# Altium schematic sheet Schematic.SchDoc
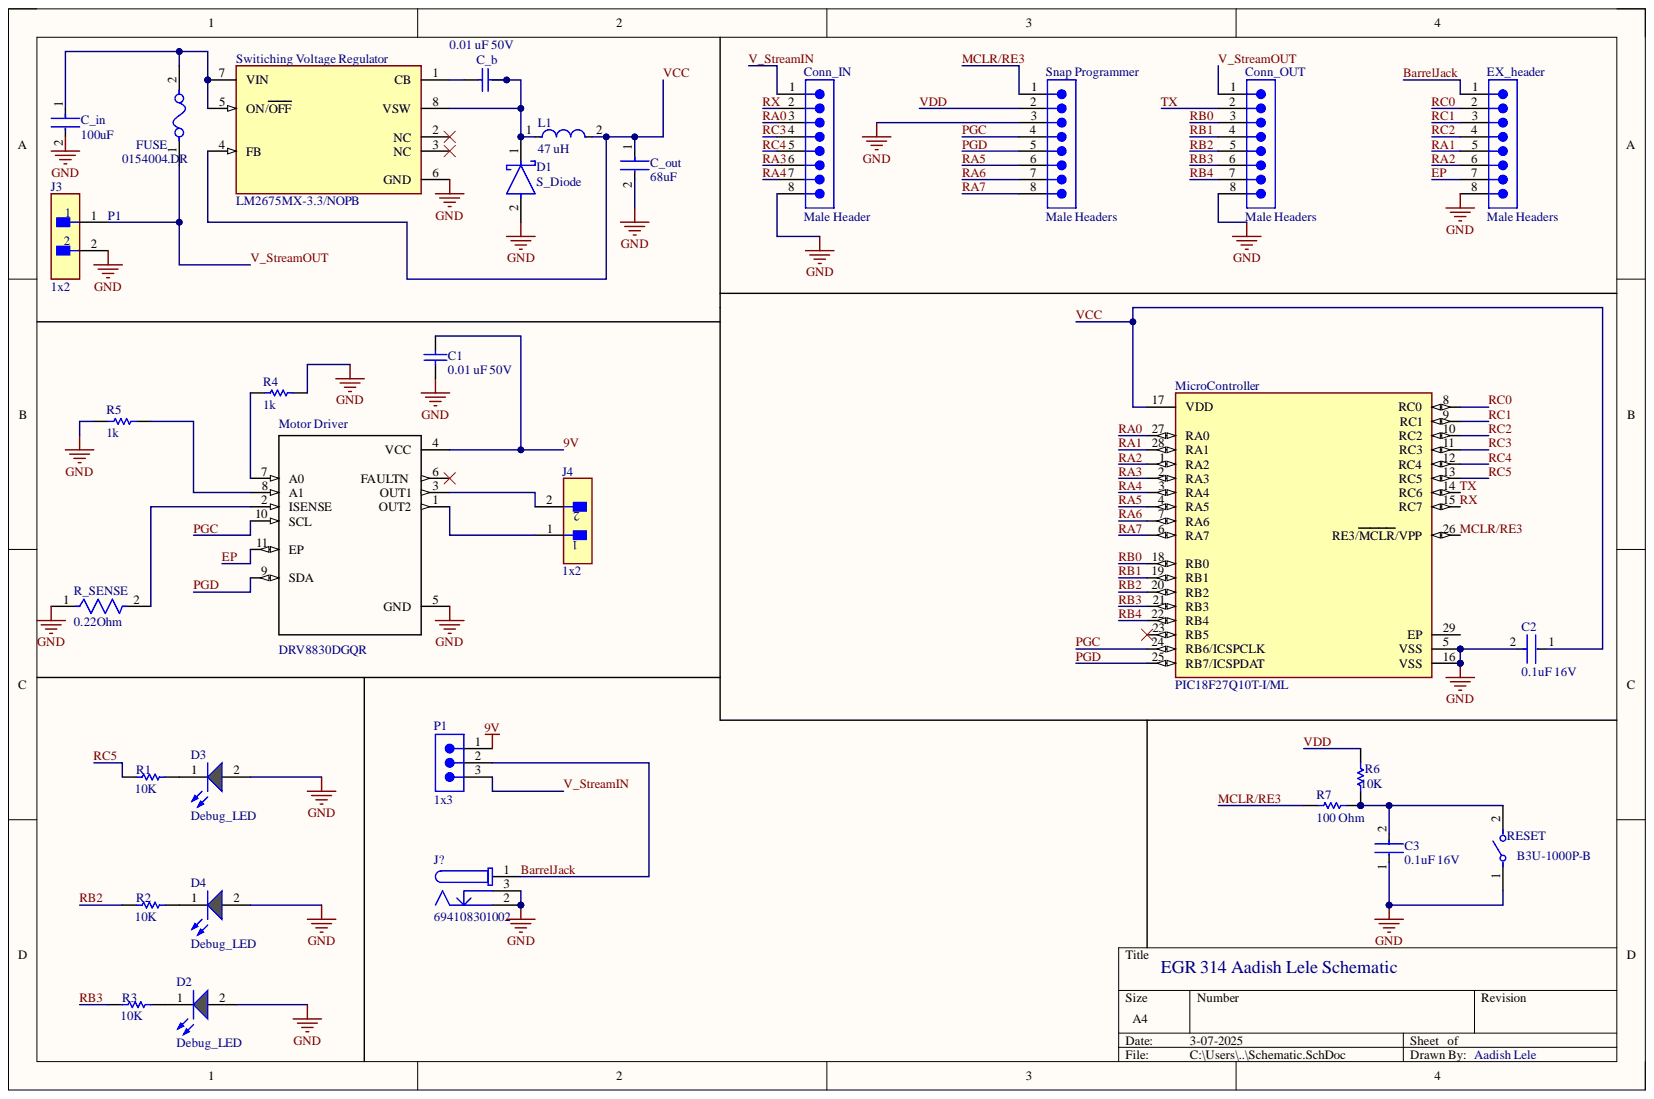
[diagram p0: Schematic.SchDoc]

## Parts
C1  0.01 uF 50V  [CAPC1812(4532)230_M]
C2  0.1uF 16V  [FP-GRM15-MFG]
C3  0.1uF 16V  [FP-GRM15-MFG]
C_b  0.01 uF 50V  [CAPC1812(4532)230_M]
C_in  100uF  [FP-GRM32-0_3-MFG]
C_out  68uF  [FP-C5750-230-0_4-IPC_C]
Conn_IN  Male Header  Gender: Male  [61300811121]
Conn_OUT  Male Headers  Gender: Male  [61300811121]
D1  S_Diode  [FP-SMA-MFG]
D2  Debug_LED  [WL-SMCW_0603_150060xx75000]
D3  Debug_LED  [WL-SMCW_0603_150060xx75000]
D4  Debug_LED  [WL-SMCW_0603_150060xx75000]
EX_header  Male Headers  Gender: Male  [61300811121]
FUSE  0154004.DR  [FP-0154-MFG]
J3  1x2  [FP-90136-1202-MFG]
J4  1x2  [FP-90136-1202-MFG]
J?  694108301002  [694108301002]
L1  47 uH  [FP-LB3218-1_8-0_2-IPC_A]
MicroController  PIC18F27Q10T-I/ML  [FP-C04-105-MFG]  (value not drawn)
Motor_Driver  DRV8830DGQR  [*IC_XTR111AIDGQR]
P1  1x3  [SMTC-TMM-103-01-X-S-RA]
R1  10K  [RESC3116X65X40ML10T20]
R2  10K  [RESC3116X65X40ML10T20]
R3  10K  [RESC3116X65X40ML10T20]
R4  1k  [RESC1005X40X25NL05T05]
R5  1k  [RESC1005X40X25NL05T05]
R6  10K  [RESC3116X65X40ML10T20]
R7  100 Ohm  [RESC3216X70X50NL05T20]
R_SENSE  0.22Ohm  [FP-ERJ1TR-MFG]
RESET  B3U-1000P-B  [FP-B3U-1000P-B-MFG]
Snap_Programmer  Male Headers  Gender: Male  [61300811121]
Switiching_Voltage_Regulator  LM2675MX-3.3/NOPB  [FP-D0008A-IPC_B]

## Nets
GND: C1.1 C2.2 C3.1 C_in.2 C_out.2 Conn_IN.8 Conn_OUT.8 D1.2 D2.2 D3.2 D4.2 EX_header.8 J3.2 J?.2 J?.3 MicroController.5(VSS) MicroController.16(VSS) Motor_Driver.5(GND) R4.2 R5.1 R_SENSE.1 RESET.1 Snap_Programmer.3 Switiching_Voltage_Regulator.6(GND)
9V: C1.2 Motor_Driver.4(VCC) P1.1
BarrelJack: EX_header.1 J?.1 P1.2
EP: EX_header.7 Motor_Driver.11(EP)
MCLR/RE3: MicroController.26(RE3/MCLR/VPP) R7.1 Snap_Programmer.1
PGC: MicroController.24(RB6/ICSPCLK) Motor_Driver.10(SCL) Snap_Programmer.4
PGD: MicroController.25(RB7/ICSPDAT) Motor_Driver.9(SDA) Snap_Programmer.5
RA0: Conn_IN.3 MicroController.27(RA0)
RA1: EX_header.5 MicroController.28(RA1)
RA2: EX_header.6 MicroController.1(RA2)
RA3: Conn_IN.6 MicroController.2(RA3)
RA4: Conn_IN.7 MicroController.3(RA4)
RA5: MicroController.4(RA5) Snap_Programmer.6
RA6: MicroController.7(RA6) Snap_Programmer.7
RA7: MicroController.6(RA7) Snap_Programmer.8
RB0: Conn_OUT.3 MicroController.18(RB0)
RB1: Conn_OUT.4 MicroController.19(RB1)
RB2: Conn_OUT.5 MicroController.20(RB2) R2.1
RB3: Conn_OUT.6 MicroController.21(RB3) R3.1
RB4: Conn_OUT.7 MicroController.22(RB4)
RC0: EX_header.2 MicroController.8(RC0)
RC1: EX_header.3 MicroController.9(RC1)
RC2: EX_header.4 MicroController.10(RC2)
RC3: Conn_IN.4 MicroController.11(RC3)
RC4: Conn_IN.5 MicroController.12(RC4)
RC5: MicroController.13(RC5) R1.1
RX: Conn_IN.2 MicroController.15(RC7)
TX: Conn_OUT.2 MicroController.14(RC6)
V_StreamIN: Conn_IN.1 P1.3
V_StreamOUT: Conn_OUT.1 FUSE.1 J3.1
VCC: C2.1 C_out.1 L1.2 MicroController.17(VDD) Switiching_Voltage_Regulator.4(FB)
VDD: R6.2 Snap_Programmer.2
NetC3_2: C3.2 R6.1 R7.2 RESET.2
NetC_b_1: C_b.1 Switiching_Voltage_Regulator.1(CB)
NetC_b_2: C_b.2 D1.1 L1.1 Switiching_Voltage_Regulator.8(VSW)
NetC_in_1: C_in.1 FUSE.2 Switiching_Voltage_Regulator.5(ON/OFF) Switiching_Voltage_Regulator.7(VIN)
NetD2_1: D2.1 R3.2
NetD3_1: D3.1 R1.2
NetD4_1: D4.1 R2.2
NetJ4_1: J4.1 Motor_Driver.1(OUT2)
NetJ4_2: J4.2 Motor_Driver.3(OUT1)
NetMotor Driver_2: Motor_Driver.2(ISENSE) R_SENSE.2
NetMotor Driver_7: Motor_Driver.7(A0) R4.1
NetMotor Driver_8: Motor_Driver.8(A1) R5.2

Not connected: MicroController 23(RB5) 29(EP); Motor_Driver 6(FAULTN); Switiching_Voltage_Regulator 2(NC) 3(NC)

## Library data of the parts
C1 C_b = CMP-1040-00808-2: CAP 10nF 50V ±2% 1812 (4532 Metric) Thickness 2.3mm SMD; Case-EIA: 1812; Case-Metric: 4532; Tolerance: Â±2%; Component Kind: Standard; Library Name: Altium Content Vault; Max Thickness: 2.3 mm; Rated Voltage: 50 V; Technology: SMT; Value: 10nF
C2 C3 = CMP-2100-03641-2: CAP CER 0.1UF 16V X7R 0402; Manufacturer: Murata; Manufacturer Part Number: GRM155R71C104KA88D; Capacitance: 0.10μF; Material: Ceramic; Standoff Height: 0mm; Tolerance: 10%; Value: 0.10μF; Voltage Rating: 16Vdc; Category: Cap; Component Kind: Standard; ComponentLink2Description: Datasheet; ComponentLink2URL: https://search.murata.co.jp/Ceramy/image/img/A01X/G101/ENG/GRM155R71H471KA01-01A.pdf; Device Class L1: Passive Components; Device Class L2: Capacitors; Device Class L3: Ceramic Capacitors; Digikey Description: CAP CER 0.1UF 16V X7R 0402; Height: 0.55mm; Ipc Land Pattern Name: CAPC10050X219; Lead Free: yes; Library Name: Altium Content Vault; Mouser Description: 0402 0.1 uF 16V ±10 % Tolerance X7R SMT Multilayer Ceramic Capacitor; Octopart: https://octopart.com/grm155r71c104ka88d-murata-196880; Package: GRM15; RoHS: TRUE; Temperature: -55°C to +125°C; Temperature Characteristic: X7R; Temperature Range High: +125°C; Temperature Range Low: -55°C
C_in = CMP-2000-05259-2: CAP CER 100UF 6.3V X5R 1210; 3DS Part Number: 220-00002; Manufacturer: Murata; Manufacturer Part Number: GRM32ER60J107ME20L; Temperature Coefficient: 15%; Tolerance: 20%; Automotive: No; Capacitance: 100uF; Category: Cap; Component Kind: Standard; ComponentLink2Description: Datasheet; ComponentLink2URL: https://search.murata.co.jp/Ceramy/image/img/A01X/G101/ENG/GRM32ER60J107ME20-01.pdf; Device Class L1: Passive Components; Device Class L2: Capacitors; Device Class L3: Ceramic Capacitors; Digikey Description: CAP CER 100UF 6.3V X5R 1210; Height: 2.7mm; Ipc Land Pattern Name: CAPC320250X250; Lead Free: Yes; Library Name: Altium Content Vault; Material: Ceramic; Octopart: https://octopart.com/grm32er60j107me20l-murata-197007; Package: GRM32-0.3; RoHS: TRUE; Temperature: -55°C to +85°C; Temperature Characteristic: X5R; Temperature Range High: +85°C; Temperature Range Low: -55°C; Value: 100uF; Voltage: 6.3V; Voltage Rating: 6.3V
C_out = CMP-08246-004950-1: Multilayer Ceramic Capacitors 68uF ±20% 10V X5R SMD 2220; Capacitance: 68uF; Case Code (Imperial): 2220; Case Code (Metric): 5750; Case/Package: 2220; Component Kind: Standard; Construction: Flat; Depth: 5mm; Dielectric: X5R; Dielectric Material: Ceramic; Height: 2.3mm; Lead Free: Lead Free; Length: 5.7mm; Library Name: Altium Content Vault; Max Operating Temperature: 85°C; Min Operating Temperature: -55°C; Mount: Surface Mount; Number of Pins: 2; Package Quantity: 1; Packaging: Tape and Reel; Radiation Hardening: No; REACH SVHC: No SVHC; Resistor Type: Ceramic; RoHS Compliant: Yes; Series: C; Termination: SMD/SMT; Thickness: 0.098inch; Tolerance: 20%; Voltage: 10V; Voltage Rating: 10V; Voltage Rating (DC): 10V; Weight: 0.016932oz; Width: 0.197inch
Conn_IN Conn_OUT EX_header Snap_Programmer = CMP-1502-01076-3: NexarPartId: 32855533; Connector Type: Male Pin; Contact Resistance: 20mΩ; Current Rating: 3A; Number of Contacts: 8; Operating Supply Voltage: 250V; Pitch: 2.54mm; Color: Black; Component Kind: Standard; Contact Material: Copper; Contact Mating Length: 5.9944mm; Contact Plating: Gold; Height: 8.54mm; Lead Pitch: 2.54mm; Library Name: Altium Content Vault; Max Operating Temperature: 105°C; Min Operating Temperature: -40°C; Mount: Through Hole; Number of Pins: 8; Number of Positions: 8; Number of Rows: 1; Orientation: Vertical; Packaging: Bulk; REACH SVHC: Unknown; RoHS: Compliant; Termination: Solder; Voltage Rating: 250V
D1 = CMP-12790-000051-1: DIODE SCHOTTKY 20V 1A SMA; Manufacturer: Diodes Inc.; Manufacturer Part Number: B120-13-F; Capacitance: 110pF; Max Junction Temp: +150°C; Max Surge Forward Current: 30A; Peak Reverse Current: 0.5mA; Standoff Height: 0.05mm; Value: 110pF; Automotive: No; Category: Diode; Component Kind: Standard; ComponentLink2Description: Datasheet; ComponentLink2URL: https://www.diodes.com/assets/Datasheets/ds13002.pdf; Device Class L1: Discrete Semiconductors; Device Class L2: Diodes; Device Class L3: Schottky Diodes; Digikey Description: DIODE SCHOTTKY 20V 1A SMA; Footprint Url: https://www.diodes.com/assets/Package-Files/SMA.pdf; Forward Current: 1A; Height: 2.4mm; Lead Free: Yes; Library Name: Altium Content Vault; Max Repetitive Reverse Voltage: 20V; Mouser Description: Schottky Diodes & Rectifiers 20V 1A; Octopart: https://octopart.com/b120-13-f-diodes+inc.-40148653; Package: SMA; Reverse Voltage: 20V; RoHS: TRUE; Temperature: -65°C to +150°C; Temperature Range High: +150°C; Temperature Range Low: -65°C
D2-D4 = CMP-1426-00006-7: WURTH ELEKTRONIK - 150060VS75000 - LED, Green, SMD, 0603, 30 mA, 2 V, 570 nm; Dominant Wavelength: 570nm; Forward Current: 100mA; Forward Voltage: 2V; Lens Style: Clear, Rectangular; Luminous Intensity: 40mcd; Max Junction Temperature (Tj): 95°C; Max Reverse Voltage (DC): 5V; Peak Wavelength: 572nm; Power Dissipation: 72mW; Test Current: 20mA; Viewing Angle: 140°; Case Code (Imperial): 0603; Case Code (Metric): 1608; Case/Package: 0603; Color: Green; Component Kind: Standard; Height: 700µm; Length: 1.6mm; Library Name: Altium Content Vault; Max Operating Temperature: 85°C; Min Operating Temperature: -40°C; Mount: Surface Mount; Number of Pins: 2; Packaging: Tape and Reel; REACH SVHC: Unknown; Reverse Voltage: 5V; RoHS: Compliant; Wavelength: 570nm; Width: 800µm
FUSE = CMP-08605-000003-1: FUSE BRD MNT 4A 125VAC/VDC 2SMD; Manufacturer: Littelfuse; Fuse Blow Speed: Fast; Max Fuse Ac Voltage: 125V; Automotive: No; Category: Fuse; Component Kind: Standard; ComponentLink2Description: Datasheet; ComponentLink2URL: https://www.littelfuse.com/~/media/electronics/datasheets/fuses/littelfuse_fuse_154_154t_154l_154tl_datasheet.pdf.pdf; Device Class L1: Circuit Protection; Device Class L2: Fuses; Device Class L3: unset; Digikey Description: FUSE BRD MNT 4A 125VAC/VDC 2SMD; Fuse Current: 4A; Height: 3.81mm; Lead Free: Yes; Library Name: Altium Content Vault; Manufacturer Part Number: 0154004.DR; Max Fuse Dc Voltage: 125V; Mouser Description: Surface Mount Fuses Fuseblock w/ fuse 4A OMNI BLOK 154; Octopart: https://octopart.com/0154004.dr-littelfuse-565861; Package: 0154; RoHS: TRUE; Temperature: -55°C to +125°C; Temperature Range High: +125°C; Temperature Range Low: -55°C
J3 J4 = CMP-04776-000259-1: CONN HEADER VERT 2POS 2.54MM; Contact Resistance: 20mR; Current Rating: 3A; Gender: Male; Glow Wire Compliant: Non-compliant; Insulation Resistance: 5GR; Max Voltage Rating (DC): 350.35kV; Number of Circuits: 2; Voltage Rating: 350V; Color: Black; Component Kind: Standard; Contact Material: Brass; Contact Plating: Gold; Flammability Rating: UL94 V-0; Housing Color: Black; Housing Material: Polyester; Lead Free: Lead Free; Lead Pitch: 2.54mm; Library Name: Altium Content Vault; Max Operating Temperature: 125°C; Min Operating Temperature: -55°C; Mount: Through Hole; Number of Contacts: 2; Number of Poles: 2; Number of Positions: 2; Number of Rows: 1; Orientation: Vertical; Package Quantity: 3040; Packaging: Tray; Pitch: 2.54mm; Radiation Hardening: No; RoHS Compliant: Yes; Series: C-Grid III; Termination: Through Hole; Voltage Rating (AC): 350V; Voltage Rating (DC): 350V; Working Voltage: 350V
J? = CMP-1502-00190-3: NexarPartId: 52676102; Connector Type: Power; Current Rating: 5A; Gender: Male; Insulation Resistance: 100MΩ; Number of Contacts: 3; Operating Supply Voltage: 24V; Shielding: Unshielded; Voltage Rating (DC): 20V; Color: Black; Component Kind: Standard; Contact Gender: Female; Contact Material: Gold, Tin; Contact Plating: Tin; Flammability Rating: UL94 V-0; Height: 11mm; Housing Material: Polyamide; Library Name: Altium Content Vault; Max Operating Temperature: 85°C; Min Operating Temperature: -40°C; Mount: Through Hole; Packaging: Bulk; REACH SVHC: Unknown; RoHS: Compliant; Termination: Through Hole; Voltage Rating: 24V
L1 = CMP-14484-000479-1: SMD Inductors 47uH ±10% 0.205A 1.196Ω 1207; Core Material: Ferrite; Current Rating: 205mA; DC Resistance (DCR): 920mR; Self Resonant Frequency: 12MHz; Shielding: Unshielded; Test Frequency: 2.52MHz; Case Code (Imperial): 1207; Case Code (Metric): 3218; Case/Package: 1207; Component Kind: Standard; DC Current: 205mA; Depth: 2mm; Halogen Free: Halogen Free; Height: 1.8mm; Height - Seated (Max): 0.08inch; Inductance: 47uH; Lead Free: Lead Free; Lead Pitch: 1.7mm; Length: 3.2mm; Library Name: Altium Content Vault; Max DC Current: 205mA; Max Operating Temperature: 105°C; Military Standard: Not; Min Operating Temperature: -40°C; Mount: Surface Mount; Number of Pins: 2; Package Quantity: 2000; Packaging: Tape and Reel; Radiation Hardening: No; Resistor Type: Wirewound; RoHS Compliant: Yes; Series: LB; Termination: SMD/SMT; Tolerance: 10%; Width: 1.8mm
MicroController = CMP-00252-96705243-1: PIC18F27Q10T-I/ML 8-bit PIC MCU, 128 KB, 3615 Bytes, 64 MHz, 1.8 to 5.5V, QFN-28, RoHS; Data Bus Width: 8b; Frequency: 64MHz; Interface: I2C, LIN, SMBus, SPI, USART; Max Junction Temperature (Tj): 150°C; Memory Size: 128kB; Memory Type: FLASH; Min Supply Voltage: 1.8V; Number of A/D Converters: 1; Number of ADC Channels: 24; Number of I2C Channels: 2; Number of I/Os: 25; Number of Timers/Counters: 4; RAM Size: 3.5kB; Ambient Temperature Range High: 85°C; Component Kind: Standard; Core Architecture: PIC; Height: 1mm; Library Name: Altium Content Vault; Lifecycle Status: Production; Max Operating Temperature: 85°C; Max Supply Voltage: 5.5V; Min Operating Temperature: -40°C; NexarPartId: 96705243; Number of PWM Channels: 2; Number of SPI Channels: 2; Number of UART Channels: 2
Motor_Driver = DRV8830DGQR: 7-V, 1-A H-bridge motor driver with speed regulation and I2C control; MF: Texas Instruments; Check_prices: https://www.snapeda.com/parts/DRV8830DGQR/Texas+Instruments/view-part/?ref=eda; Component Kind: Standard; DigiKey_Part_Number: 296-28165-2-ND; Library Name: DRV8830DGQR.SchLib; MP: DRV8830DGQR; Package: HVSSOP-10 Texas Instruments; SnapEDA_Link: https://www.snapeda.com/parts/DRV8830DGQR/Texas+Instruments/view-part/?ref=snap
P1 = CMP-1029-00176-1: Low Profile Through-Hole Header, Right Angle, -55 to 105 degC, 2 mm Pitch, 3-Pin, Male, RoHS; Manufacturer: Samtec; PartNumber: TMM-103-01-T-S-RA; Pitch: 2 mm; Component Kind: Standard; ComponentLink1Description: Manufacturer URL; ComponentLink1URL: http://www.samtec.com; ComponentLink2Description: Datasheet; ComponentLink2URL: http://d2a072wq521u33.cloudfront.net/catalog_english//TMM_TH.PDF; ComponentLink3Description: Package Specification; ComponentLink3URL: http://www.samtec.com/documents/webfiles/cpdf/TMM-1XX-XX-XX-X-RA-XXX-MKT.pdf; DatasheetVersion: F-214; Library Name: Altium Content Vault; Mounting Technology: Through-hole; Orientation: Right Angle; PackageDescription: 2 mm Terminal Strip Assembly (R/A); PackageReference: TMM-103-01-X-S-RA; PackageVersion: V, 3/2013; RoHS: True; Temperature Range: -55 to 105 degC
R1-R3 R6 = CMP-1014-00623-2: Chip Resistor, 10 KOhm, +/- 1%, 0.25 W, -55 to 155 degC, 1206 (3216 Metric), RoHS, Tape and Reel; Manufacturer: Yageo; Power Rating: 250mW; Resistance: 10KOhm; Temperature Coefficient: 100ppm/degreesC; Voltage Rating: 200V; Case Code (Imperial): 1206; Case Code (Metric): 3216; Case/Package: 1206; Component Kind: Standard; Composition: ThickFilm; Datasheet URL: http://www.yageo.com/NewPortal/yageodocoutput?fileName=/pdf/R-Chip/PYu-RC_Group_51_RoHS_L_5.pdf; Datasheet Version: Rev. 5, 10/2016; Depth: 1.6mm; Height: 0.55mm; Lead Free: LeadFree; Length: 3.1mm; Library Name: Altium Content Vault; Manufacturer URL: http://www.yageo.com/; Max Operating Temperature: 155degC; Military Standard: Not; Min Operating Temperature: -55degC; Package Description: 2-Pin Surface Mount Device, Body 3.1 x 1.6 mm; Package Quantity: 5000; Package Reference: 1206.0; Packaging: TapeandReel; REACH SVHC: Unknown; RoHSCompliant: true; Termination: SMD/SMT; Tolerance: 1%; Width: 1.6mm
R4 R5 = CMP-1011-00510-4: Chip Resistor, 1 KOhm, +/- 1%, 100 mW, -55 to 155 degC, 0402 (1005 Metric), RoHS, Tape and Reel; Manufacturer: Basic Passives; PartNumber: ERJ-2RKF1001X; Case\/Package: 0402; Power Rating: 100 mW; Resistance: 1 KOhm; Voltage Rating: 50 V; Case Code (Imperial): 0402; Case Code (Metric): 1005; Component Kind: Standard; ComponentLink1Description: Manufacturer URL; ComponentLink1URL: http://www.panasonic.com/; ComponentLink2Description: Datasheet; ComponentLink2URL: http://industrial.panasonic.com/cdbs/www-data/pdf/RDA0000/AOA0000C86.pdf; DatasheetVersion: 04/2015; Height: 0.35 mm; Length: 1 mm; Library Name: Altium Content Vault; Max Operating Temperature: 155 degC; Min Operating Temperature: -55 degC; Mount: Surface Mount; Mounting Technology: Surface Mount; Package Quantity: 10000; PackageDescription: 2-Pin Surface Mount Device, Body 1 x 0.5 mm; PackageReference: 0402; Packaging: Tape and Reel; Pins: 2; Radiation Hardening: No; REACH SVHC: Yes; RoHSCompliant: true; Tolerance: 1%; Width: 0.5 mm
R7 = CMP-2003-00016-1: Manufacturer: Panasonic; Part Number: ERJ-8ENF1000V; Component Kind: Standard; Library Name: Altium Content Vault
R_SENSE = CMP-05422-000060-1: RES 0.22 OHM 1% 1W 2512; 3DS Part Number: 110-00006; Manufacturer: Panasonic; Manufacturer Part Number: ERJ-1TRQFR22U; Automotive Grade: Grade 0; Resistance: 0.22Ω; Temperature Coefficient: 200ppm/°C; Value: 0.22Ω; Automotive: Yes; Category: Res; Component Kind: Standard; ComponentLink2Description: Datasheet; ComponentLink2URL: https://industrial.panasonic.com/cdbs/www-data/pdf/RDN0000/AOA0000C313.pdf; Composition: Thick Film; Device Class L1: Passive Components; Device Class L2: Resistors; Device Class L3: Chip SMD Resistors; Digikey Description: RES 0.22 OHM 1% 1W 2512; Footprint Url: https://industrial.panasonic.com/cdbs/www-data/pdf/RDN0000/DMN0000COL17.pdf; Height: 0.7mm; Ipc Land Pattern Name: RESC640320X60; Lead Free: Yes; Library Name: Altium Content Vault; Mouser Description: Current Sense Resistors 2512 0.22ohm 1% Curr Sense AEC-Q200; Octopart: https://octopart.com/erj1trqfr22u-panasonic-10566390; Package: ERJ1TR; Power Rating: 1W; RoHS: TRUE; Series: ERJ; Temperature: -55°C to +155°C; Temperature Range High: +155°C; Temperature Range Low: -55°C; Tolerance: 1%
RESET = CMP-08834-000005-1: Tactile Switch SPST-NO Top Actuated Surface Mount; Manufacturer: Omron; Contact Current Rating: 50mA; Contact Resistance: 100mΩ; Electromechanical Life: 200000Cycles; Operating Force: 1.5N; Voltage Rating Dc: 12V; Ambient Temperature Range High: +70°C; Ambient Temperature Range Low: -25°C; Automotive: No; Category: Switch; Component Kind: Standard; ComponentLink2Description: Datasheet; ComponentLink2URL: https://omronfs.omron.com/en_US/ecb/products/pdf/en-b3u.pdf; Device Class L1: Electromechanical; Device Class L2: Switches; Device Class L3: Tactile Switches; Digikey Description: SWITCH TACTILE SPST-NO 0.05A 12V; Height: 1.75mm; Lead Free: Yes; Library Name: Altium Content Vault; Manufacturer Part Number: B3U-1000P-B; Mount: Surface Mount; Mouser Description: Tactile Switches Top Actuated with boss w/o ground; Octopart: https://octopart.com/b3u-1000p-b-omron-1057356; Package: 0; RoHS: TRUE; Temperature: -25°C to +70°C; Temperature Range High: +70°C; Temperature Range Low: -25°C; Throw Configuration: SPST-NO
Switiching_Voltage_Regulator = CMP-04918-000266-1: IC REG BUCK 3.3V 1A 8SOIC; Efficiency: 86%; Max Input Voltage: 40V; Min Input Voltage: 8V; Number of Outputs: 1; Output Type: Fixed; Quiescent Current: 2.5mA; Switching Frequency: 260kHz; Synchronous Rectifier: No; Case/Package: SOIC; Component Kind: Standard; Contact Plating: Tin; Lead Free: Lead Free; Library Name: Altium Content Vault; Max Operating Temperature: 125°C; Max Output Current: 1A; Min Operating Temperature: -40°C; Mount: Surface Mount; Nominal Output Voltage: 3.3V; Number of Pins: 8; Operating Supply Voltage: 40V; Output Current: 1A; Output Voltage: 3.3V; Package Quantity: 2500; Packaging: Tape and Reel; Radiation Hardening: No; REACH SVHC: No SVHC; RoHS Compliant: Yes; Series: SIMPLE SWITCHER®
